# Altium schematic sheet USB.SchDoc
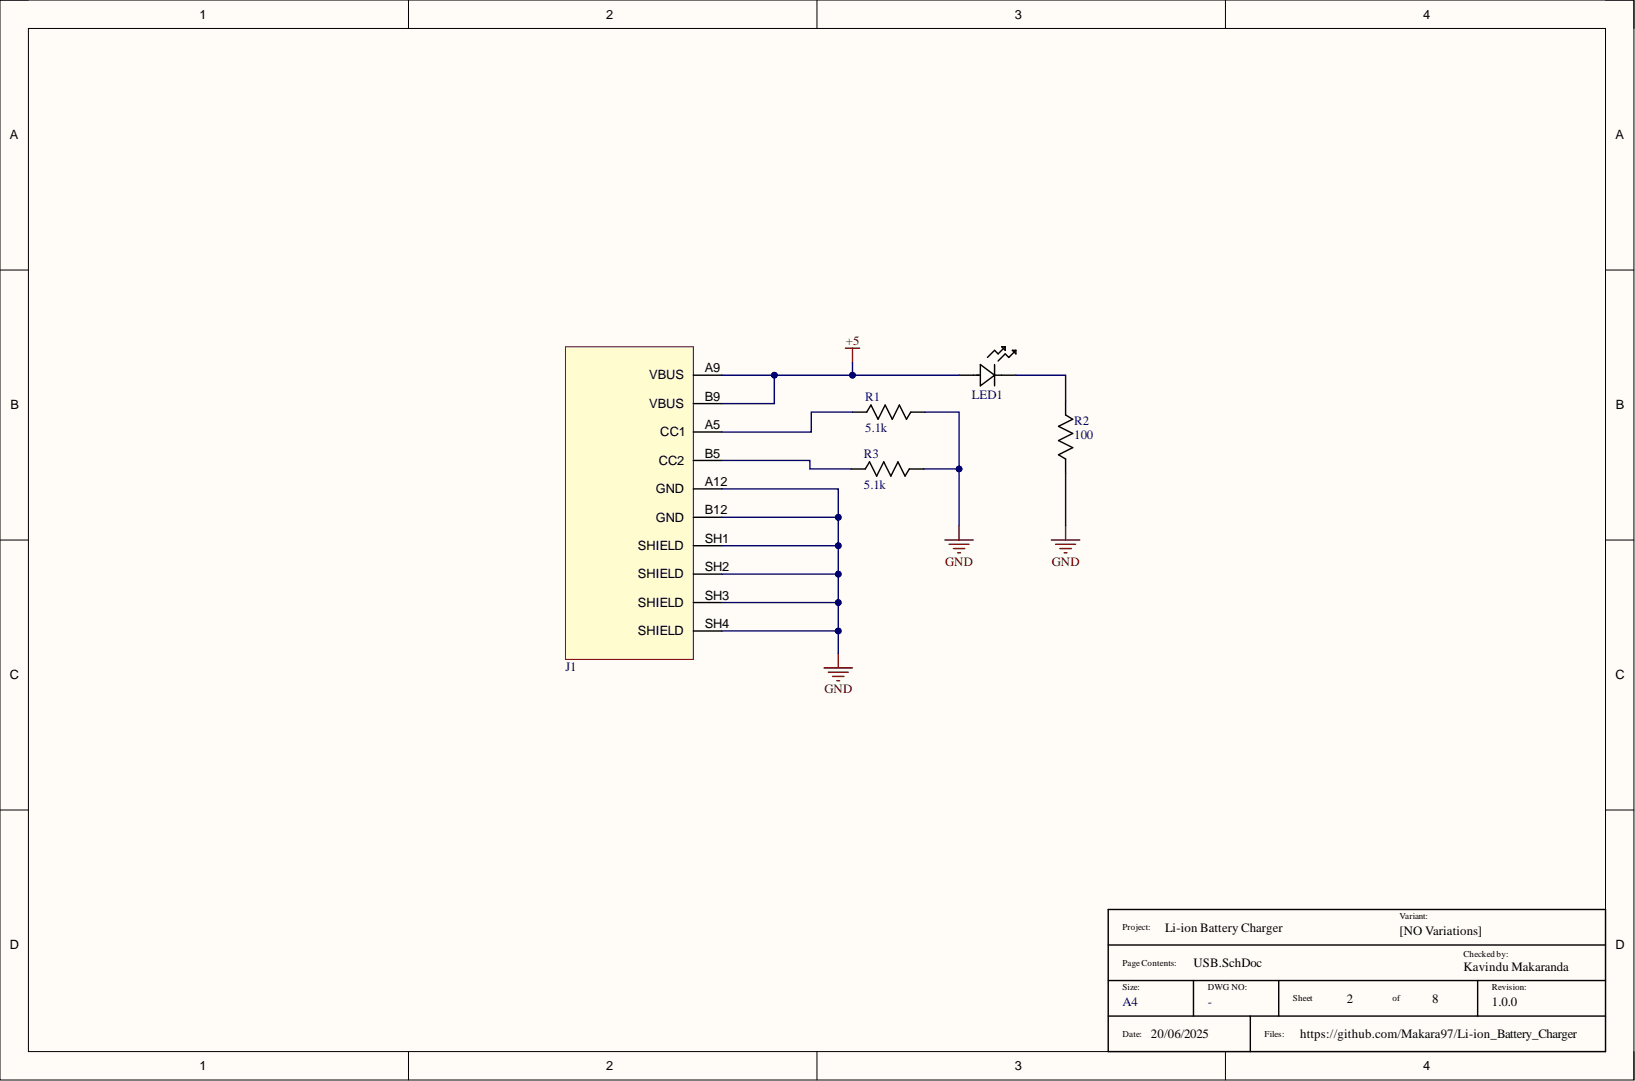
[diagram p0: USB.SchDoc]

## Parts
J1  USB_Type_C  [AMPHENOL_10174819-00011LF]  (value not drawn)
LED1  LED GREEN DIFFUSED 0603 SMD  [LED_B1931NG_HVK]  (value not drawn)
R1  5.1k  [RESC1608X55N]
R2  100  [RESC1608X55N]
R3  5.1k  [RESC1608X55N]

## Nets
+5: J1.A9(VBUS) J1.B9(VBUS) LED1.2
GND: J1.A12(GND) J1.B12(GND) J1.SH1(SHIELD) J1.SH2(SHIELD) J1.SH3(SHIELD) J1.SH4(SHIELD) R1.2 R2.1 R3.2
NetJ1_A5: J1.A5(CC1) R1.1
NetJ1_B5: J1.B5(CC2) R3.1
NetLED1_1: LED1.1 R2.2

## Library data of the parts
J1 = 10174819-00011LF: USB-C (USB TYPE-C) Receptacle Connector 24 (6+18 Dummy) Position Surface Mount, Right Angle; MF: Amphenol Communications Solutions-FCI; Check_prices: https://www.snapeda.com/parts/10174819-00011LF/Amphenol/view-part/?ref=eda; Component Kind: Standard; Library Name: 10174819-00011LF.IntLib; MP: 10174819-00011LF; Package: None; SnapEDA_Link: https://www.snapeda.com/parts/10174819-00011LF/Amphenol/view-part/?ref=snap; Supplier 1: Digikey; Supplier Part Number 1: 609-10174819-00011LFCT-ND
LED1 = B1931NG--20D001114U1930: Mfr_Name: Harvatek; Type: LED Indication; Component Kind: Standard; Description: LED GREEN DIFFUSED 0603 SMD; Library Name: B1931NG--20D001114U1930.IntLib; Supplier 1: Digikey; Supplier Part Number 1: 3147-B1931NG--20D001114U1930CT-ND
R1 R3 = RC0603FR-075K1L: Resistor RC0603FR-075K1L; Manufacturer_Name: KEMET; Arrow Part Number: RC0603FR-075K1L; Arrow Price/Stock: https://www.arrow.com/en/products/rc0603fr-075k1l/yageo?region=nac; Component Kind: Standard; Datasheet Link: http://www.yageo.com/documents/recent/PYu-RC0603_51_RoHS_L_v5.pdf; Height: 0.55mm; Library Name: SamacSys.SchLib; Manufacturer_Part_Number: RC0603FR-075K1L; Mouser Part Number: 603-RC0603FR-075K1L; Mouser Price/Stock: https://www.mouser.co.uk/ProductDetail/YAGEO/RC0603FR-075K1L?qs=gt6vzsuosg2jUUwxnI2PJQ%3D%3D; Supplier 1: Digikey; Supplier Part Number 1: 311-5.10KHRCT-ND
R2 = ERJ-3EKF1000V: Resistor ERJ-3EKF1000V; Manufacturer_Name: Panasonic; Arrow Part Number: ERJ-3EKF1000V; Arrow Price/Stock: https://www.arrow.com/en/products/erj-3ekf1000v/panasonic?utm_currency=USD&region=nac; Component Kind: Standard; Datasheet Link: http://industrial.panasonic.com/cdbs/www-data/pdf/RDA0000/AOA0000C86.pdf; Height: 0.55mm; Library Name: SamacSys.SchLib; Manufacturer_Part_Number: ERJ-3EKF1000V; Mouser Part Number: 667-ERJ-3EKF1000V; Mouser Price/Stock: https://www.mouser.co.uk/ProductDetail/Panasonic/ERJ-3EKF1000V?qs=H7k1u0Mp9JS7i8KGO5m47A%3D%3D; Supplier 1: Mouser; Supplier Part Number 1: 667-ERJ-3EKF1000V
